# Altium schematic sheet uINS.SchDoc(U_uINS)
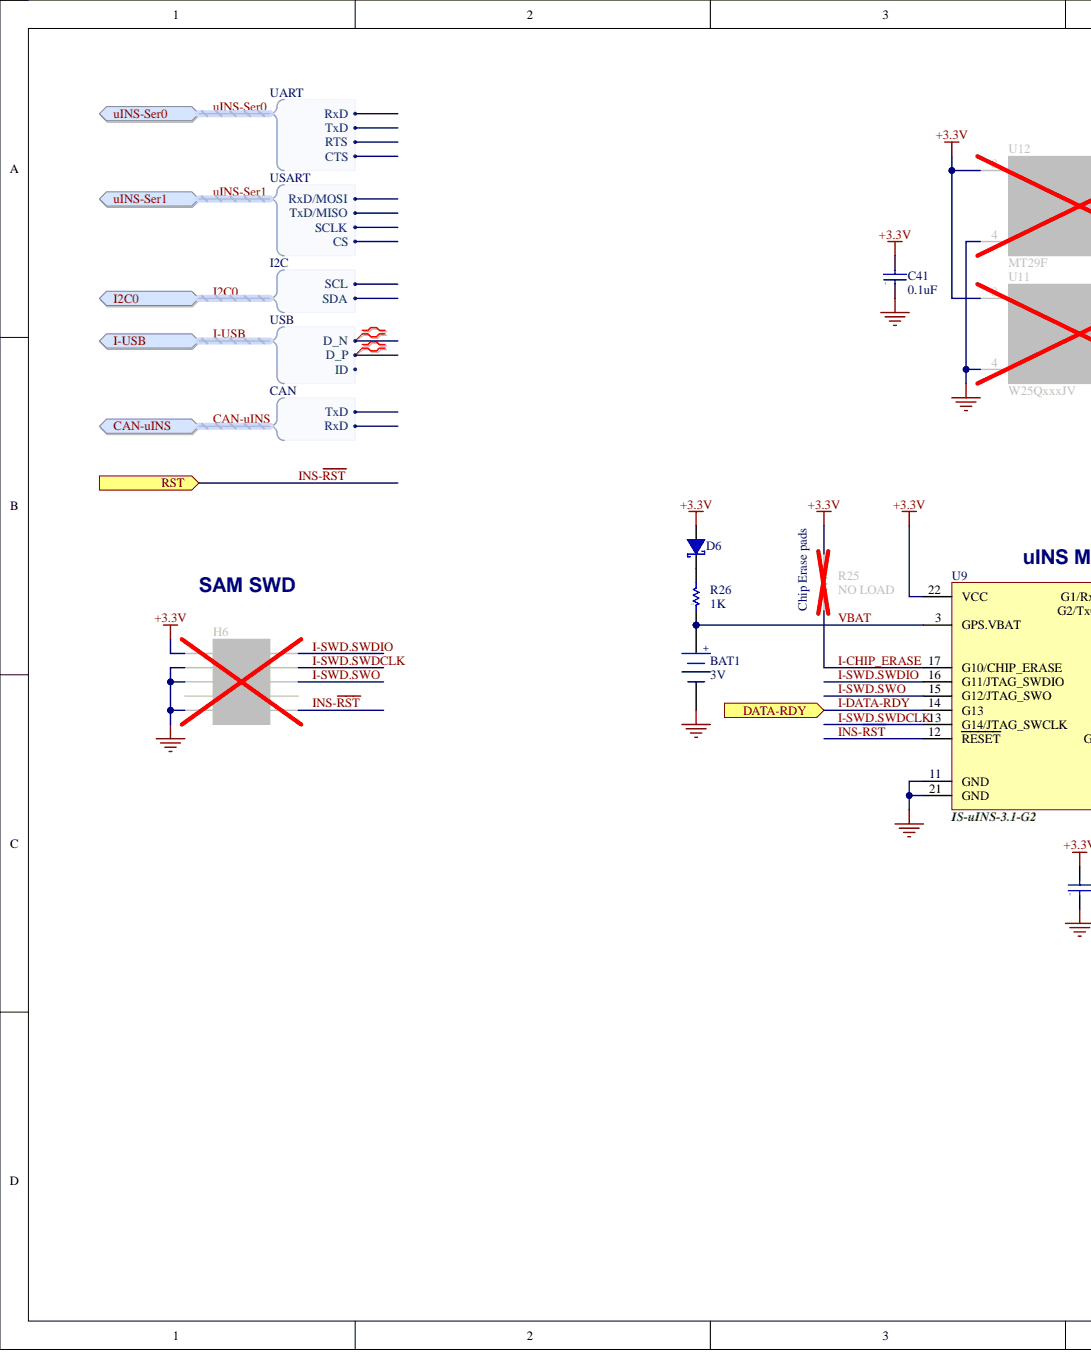
[diagram p0: uINS.SchDoc, left]
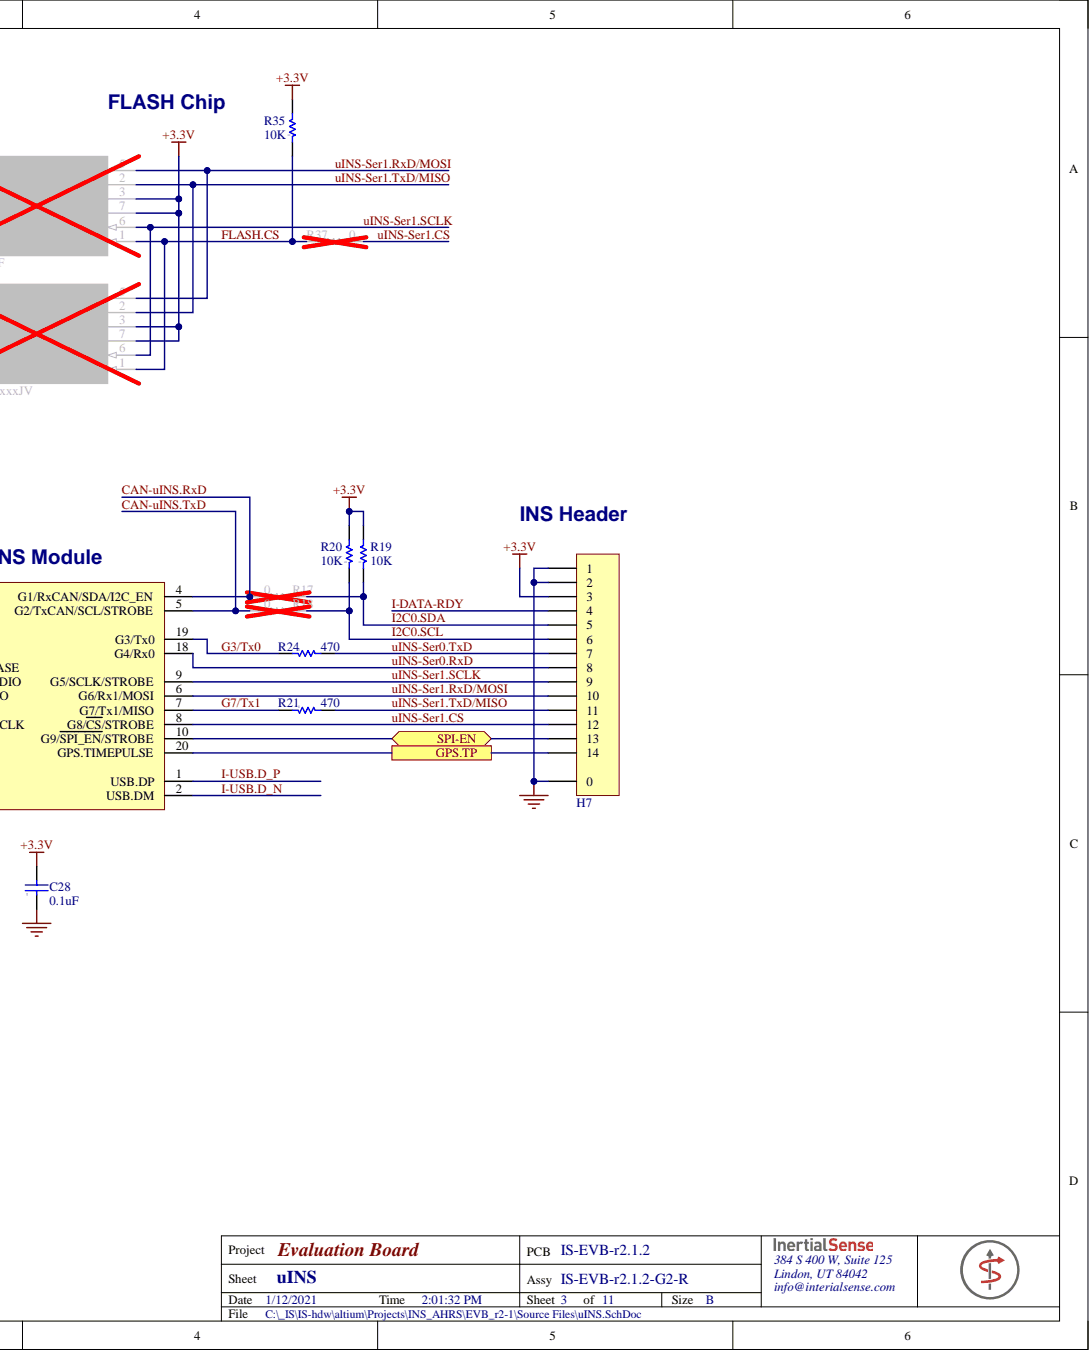
[diagram p1: uINS.SchDoc, right]

## Parts
BAT1  Voltage Rating: 3V  [ML614S]  (hidden comment: Battery)
C28  value 0.1uF  [0402]  (hidden comment: Cap)
C41  value 0.1uF  [0402]  (hidden comment: Cap)
D6  Diode - Schottky  [D0603B]  (value not drawn)
H6  Hdr - 5x2pin  [FTSH-105-01-L-DV-K-A-P]  (value not drawn)
H7  Hdr - 14pin - mount  [SM14B-GHS-TB(LF)(SN)]  (value not drawn)
R17  value 0  [R0402]  (hidden comment: Res)
R18  value 0  [R0402]  (hidden comment: Res)
R19  value 10K  [R0402]  (hidden comment: Res)
R20  value 10K  [R0402]  (hidden comment: Res)
R21  value 470  [R0402]  (hidden comment: Res)
R24  value 470  [R0402]  (hidden comment: Res)
R25  value NO LOAD  Resistance (Ohms): NO LOAD  [R0402]  (hidden comment: Res)
R26  value 1K  [R0402]  (hidden comment: Res)
R35  value 10K  [R0402]  (hidden comment: Res)
R37  value 0  [R0402]  (hidden comment: Res)
U9  uINS  Manufacturer Part Number: IS-uINS-3.1-G2  [IS-uINS-3.2_no_paste]
U11  W25QxxxJV  [SOIC127P790X216-8N]
U12  MT29F  [DFN800X600X65-8N]

## Ports
CAN-uINS, CAN-uINS.RxD, CAN-uINS.TxD, DATA-RDY, GPS.TP, I2C0, I2C0.SCL, I2C0.SDA, I-USB, I-USB.D_N, I-USB.D_P, I-USB.ID, SPI-EN, uINS-Ser0, uINS-Ser0.CTS, uINS-Ser0.RTS, uINS-Ser0.RxD, uINS-Ser0.TxD, uINS-Ser1, uINS-Ser1.CS, uINS-Ser1.RxD/MOSI, uINS-Ser1.SCLK, uINS-Ser1.TxD/MISO, ~{RST}

## Nets
+3.3V: C28.2 C41.2 D6.A H6.1 H7.3 R19.2 R20.2 R25.2 R35.2 U9.22(VCC) U11.3(WP/SIO2) U11.7(HOLD/SIO3) U11.8(VCC) U12.3(WP/SIO2) U12.7(HOLD/SIO3) U12.8(VCC)
GND: BAT1.- C28.1 C41.1 H6.3 H6.5 H6.9 H7.0 H7.1 H7.2 U9.11(GND) U9.21(GND) U11.4(GND) U12.4(GND)
CAN-uINS.RxD: R17.1 U9.4(G1/RxCAN/SDA/I2C_EN) ; port CAN-uINS
CAN-uINS.TxD: R18.1 U9.5(G2/TxCAN/SCL/STROBE) ; port CAN-uINS
FLASH.CS: R35.1 R37.1 U11.1(CS) U12.1(CS)
G3/Tx0: R24.1 U9.19(G3/Tx0)
G7/Tx1: R21.1 U9.7(G7/Tx1/MISO)
GPS.TP: H7.14 U9.20(GPS.TIMEPULSE) ; port GPS.TP
I2C0.SCL: H7.6 R18.2 R20.1 ; port I2C0
I2C0.SDA: H7.5 R17.2 R19.1 ; port I2C0
I-CHIP_ERASE: R25.1 U9.17(G10/CHIP_ERASE)
I-DATA-RDY: H7.4 U9.14(G13) ; port DATA-RDY
I-SWD.SWDCLK: H6.4 U9.13(G14/JTAG_SWCLK)
I-SWD.SWDIO: H6.2 U9.16(G11/JTAG_SWDIO)
I-SWD.SWO: H6.6 U9.15(G12/JTAG_SWO)
I-USB.D_N: U9.2(USB.DM) ; port I-USB
I-USB.D_P: U9.1(USB.DP) ; port I-USB
INS-~{RST}: H6.10 U9.12(RESET) ; port ~{RST}
SPI-EN: H7.13 U9.10(G9/SPI_EN/STROBE) ; port SPI-EN
uINS-Ser0.RxD: H7.8 U9.18(G4/Rx0) ; port uINS-Ser0
uINS-Ser0.TxD: H7.7 R24.2 ; port uINS-Ser0
uINS-Ser1.CS: H7.12 R37.2 U9.8(G8/CS/STROBE) ; port uINS-Ser1
uINS-Ser1.RxD/MOSI: H7.10 U9.6(G6/Rx1/MOSI) U11.5(DI/SIO0) U12.5(DI/SIO0) ; port uINS-Ser1
uINS-Ser1.SCLK: H7.9 U9.9(G5/SCLK/STROBE) U11.6(CLK) U12.6(CLK) ; port uINS-Ser1
uINS-Ser1.TxD/MISO: H7.11 R21.2 U11.2(DO/SIO1) U12.2(DO/SIO1) ; port uINS-Ser1
VBAT: BAT1.+ R26.1 U9.3(GPS.VBAT)
NetD6_C: D6.C R26.2
NetH6_7: H6.7
NetH6_8: H6.8
I-USB.ID: ; port I-USB
uINS-Ser0.CTS: ; port uINS-Ser0
uINS-Ser0.RTS: ; port uINS-Ser0

## Library data of the parts
BAT1 = Battery: BATTERY LITH 3V RECHARGE SMD; Manufacturer: Seiko; Manufacturer Part Number: MS621T-FL11E; Capacity: 3mAh; Component Kind: Standard; ComponentLink2Description: https://www.sii.co.jp/en/me/files/2018/04/MicroBattery_catalogue_E_2018_forWeb.pdf?src-supplier=Digi-Key; ComponentLink2URL: https://www.sii.co.jp/en/me/files/2018/04/MicroBattery_catalogue_E_2018_forWeb.pdf?src-supplier=Digi-Key; Height: 0.08inch; Library Name: General.SchLib; Pricing 1: 1=2.60000, 10=2.15200, 50=1.89740, 100=1.79140, 300=1.66420, 500=1.57940, 1000=1.49460, 2500=1.42040 (USD); RoHS: Yes; Stock 1: 1968; Supplier 1: Digi-Key; Supplier Part Number 1: 728-1080-ND; Termination: SMD/SMT; Weight: 0.23g
C28 C41 = Cap: CAP CER .10UF 50V X7R 10% 0402; Manufacturer: TDK Corporation; Manufacturer Part Number: C1005X7R1H104K; Capacitance: 0.1µF; Applications: General Purpose; Category: Capacitors; Component Kind: Standard; ComponentLink1Description: http://www.tdk.co.jp/tefe02/e412_c.pdf; ComponentLink1URL: http://www.tdk.co.jp/tefe02/e412_c.pdf; Features: -; Height: -; LatestRevisionDate: 17-Jul-2002; LatestRevisionNote: Re-released for DXP Platform.; Lead Spacing: -; Lead Style: -; Library Name: General.SchLib; Mounting Type: Surface Mount, MLCC; Operating Temperature: -55°C ~ 125°C; Package / Case: 0402 (1005 Metric); Packaging: Cut Tape (CT); Pricing 1: 1=0.3, 10=0.2, 100=0.08, 250=0.05752, 500=0.041, 1000=0.03 (USD); Published: 8-Jun-2000; Ratings: -; RoHS: RoHS Compliant; Signal Integrity: Cap; Simulation: CAP; Size / Dimension: 0.039" L x 0.020" W (1.00mm x 0.50mm); Stock 1: 174080; Supplier 1: Digi-Key; Supplier Part Number 1: 445-5932-1-ND; Temperature Coefficient: X7R; Thickness: 0.022" (0.55mm); Tolerance: ±10%; Voltage - Rated: 50V; Publisher: Altium Limited
D6 = Diode - Schottky: DIODE SCHOTTKY 30V 2A 0603; Manufacturer: Vishay; Manufacturer Part Number: VSKY20301608-G4-08; Component Kind: Standard; ComponentLink1Description: http://www.mouser.com/ds/2/427/vsky20301608-777242.pdf; ComponentLink1URL: http://www.mouser.com/ds/2/427/vsky20301608-777242.pdf; Library Name: General.SchLib; Pricing 1: 1=0.38, 10=0.305, 25=0.2792, 100=0.2076, 250=0.1882, 500=0.15574, 1000=0.1168, 2500=0.10707 (USD); Simulation: SKYDIODE; Stock 1: 13064; Supplier 1: Digi-Key; Supplier Part Number 1: VSKY20301608-G4-08GICT-ND
H6 = Hdr - 5x2pin: CONN HEADER 10POS DUAL .05" SMD; Manufacturer: Samtec; Gender: Male; Number of Contacts: 10; Pitch: 1.27mm; Component Kind: Standard; ComponentLink1Description: http://www.samtec.com/documents/webfiles/pdf/FTSH_SMT.pdf; ComponentLink1URL: http://www.samtec.com/documents/webfiles/pdf/FTSH_SMT.pdf; Contact Material: Bronze; Contact Plating: Gold; Depth: 3.43mm; Housing Colour: Black; Housing Material: Polymer; Lead Free: Lead Free; Length: 6.35mm; Library Name: Connectors.SchLib; Manufacturer Part Number: FTSH-105-01-L-DV-K-A-P; Max Operating Temperature: 125°C; Min Operating Temperature: -55°C; Number of Rows: 2; Orientation: Straight; Packaging: Bulk; Pricing 1: 1=4.15, 92=3.48598, 184=3.32, 552=2.82199, 1012=2.407 (USD); Radiation Hardening: No; Stock 1: 3679; Supplier 1: Digi-Key; Supplier Part Number 1: SAM9440-ND; Termination: Solder
H7 = Hdr - 14pin - mount: CONN HEADER GH SIDE 14POS 1.25MM; Manufacturer: JST; Connector Type: Outer Shroud Contact; Current Rating: 1A; Gender: Male; Number of Contacts: 14; Pitch: 0.049inch; Voltage Rating (AC): 50V; Wire/Cable Gauge: 26AWG; Colour: Natural; Component Kind: Standard; Contact Material: Bronze; Contact Plating: Tin; Fastening Type: Ramp; Housing Colour: Natural; Housing Material: Nylon; Lead Free: Lead Free; Library Name: Connectors.SchLib; Manufacturer Part Number: SM14B-GHS-TB(LF)(SN); Max Operating Temperature: 85°C; Min Operating Temperature: -25°C; Mount: Surface Mount; Number of Positions: 14; Number of Rows: 1; Packaging: Tape and Reel; REACH SVHC: No SVHC; Stock 1: 0; Supplier 1: Digi-Key; Supplier Part Number 1: 455-1576-6-ND; Termination: Solder
R17 R18 R37 = Res: RES SMD 0 OHM JUMPER 1/16W 0402; Manufacturer: Yageo; Manufacturer Part Number: RC0402JR-070RL; Max Power Dissipation: 63mW; Power Rating: 62.5mW; Resistance: 0mR; Resistance Tolerance: ± 5%; Resistor Case Style: 0402 [1005 Metric]; Resistor Element Type: Thick Film; SVHC: No SVHC (20-Jun-2016); Temperature Coefficient: 200ppm/°C; Tolerance: 5%; Voltage Rating: 50V; Automotive Qualification Standard: -; Case Code (Imperial): 0402; Case Code (Metric): 1005; Case/Package: 0402; Component Kind: Standard; ComponentLink1Description: https://datasheet.ciiva.com/2452/pyu-rc0402-51-rohs-l-6-2452608.pdf; ComponentLink1URL: https://datasheet.ciiva.com/2452/pyu-rc0402-51-rohs-l-6-2452608.pdf; ComponentLink2Description: https://datasheet.ciiva.com/2452/pyu-r-int-thick-7-2452609.pdf; ComponentLink2URL: https://datasheet.ciiva.com/2452/pyu-r-int-thick-7-2452609.pdf; ComponentLink3Description: https://datasheet.ciiva.com/2452/pyu-r-int-thick-7-2452610.pdf; ComponentLink3URL: https://datasheet.ciiva.com/2452/pyu-r-int-thick-7-2452610.pdf; ComponentLink4Description: https://datasheet.ciiva.com/11808/0900766b80c66297-11808195.pdf; ComponentLink4URL: https://datasheet.ciiva.com/11808/0900766b80c66297-11808195.pdf; ComponentLink5Description: https://datasheet.ciiva.com/8896/pyu-rc0402-51-rohs-l-6-8896201.pdf; ComponentLink5URL: https://datasheet.ciiva.com/8896/pyu-rc0402-51-rohs-l-6-8896201.pdf; ComponentLink6Description: https://datasheet.ciiva.com/28386/pyu-rc0402-51-rohs-l-6-28386633.pdf; ComponentLink6URL: https://datasheet.ciiva.com/28386/pyu-rc0402-51-rohs-l-6-28386633.pdf; ComponentLink7Description: https://datasheet.ciiva.com/22747/1018594-22747515.pdf; ComponentLink7URL: https://datasheet.ciiva.com/22747/1018594-22747515.pdf; ComponentLink8Description: https://datasheet.ciiva.com/15189/getdatasheetpartid-326379-15189533.pdf; ComponentLink8URL: https://datasheet.ciiva.com/15189/getdatasheetpartid-326379-15189533.pdf; ComponentLink9Description: https://datasheet.ciiva.com/7983/1018594-7983535.pdf; ComponentLink9URL: https://datasheet.ciiva.com/7983/1018594-7983535.pdf; Composition: Thick Film; Contact Plating: Matte,Tin; Depth: 0.5mm; Features: Moisture Resistant; Height: 0.016inch; Lead Free: Lead Free; Length: 1mm; Library Name: General.SchLib; Max Operating Temperature: 155°C; Military Standard: Not; Min Operating Temperature: -55°C; MSL: MSL 1 - Unlimited; Number of Terminations: 2; Package Quantity: 1; Packaging: Tape and Reel; Pricing 1: 1=0.1, 10=0.017, 25=0.0124, 50=0.0096, 100=0.007, 250=0.00536, 500=0.00428, 1000=0.00315, 5000=0.00226 (USD); Product Range: -; Radiation Hardening: No; REACH SVHC: Unknown; Signal Integrity: Res; Simulation: RES; Stock 1: 39749506; Supplier 1: Digi-Key; Supplier Part Number 1: 311-0.0JRDKR-ND; Termination: SMD/SMT; Width: 0.02inch
R19 R20 R35 = Res: RES 10K OHM 1/16W 1% 0402 SMD; Manufacturer: Panasonic; Manufacturer Part Number: ERJ-2RKF1002X; Max Power Dissipation: 100mW; Power Rating: 62.5mW; Resistance: 10kR; Temperature Coefficient: 100ppm/°C; Voltage Rating: 50V; Case Code (Imperial): 0402; Case Code (Metric): 1005; Case/Package: 0402; Component Kind: Standard; ComponentLink1Description: http://www.yageo.com/documents/recent/PYu-RC0402_51_RoHS_L_6.pdf; ComponentLink1URL: http://www.yageo.com/documents/recent/PYu-RC0402_51_RoHS_L_6.pdf; ComponentLink2Description: https://datasheet.ciiva.com/7660/38568-7660787.pdf; ComponentLink2URL: https://datasheet.ciiva.com/7660/38568-7660787.pdf; ComponentLink3Description: https://datasheet.ciiva.com/11808/0900766b80c66297-11808309.pdf; ComponentLink3URL: https://datasheet.ciiva.com/11808/0900766b80c66297-11808309.pdf; ComponentLink4Description: https://datasheet.ciiva.com/7849/1784430-7849813.pdf; ComponentLink4URL: https://datasheet.ciiva.com/7849/1784430-7849813.pdf; ComponentLink5Description: https://datasheet.ciiva.com/16744/pyu-rc0402-51-rohs-l-6-r-16744005.pdf; ComponentLink5URL: https://datasheet.ciiva.com/16744/pyu-rc0402-51-rohs-l-6-r-16744005.pdf; ComponentLink6Description: https://datasheet.ciiva.com/7849/1686128-7849814.pdf; ComponentLink6URL: https://datasheet.ciiva.com/7849/1686128-7849814.pdf; ComponentLink7Description: https://datasheet.ciiva.com/7849/1784430-7849815.pdf; ComponentLink7URL: https://datasheet.ciiva.com/7849/1784430-7849815.pdf; ComponentLink8Description: https://datasheet.ciiva.com/22747/1018594-22747512.pdf; ComponentLink8URL: https://datasheet.ciiva.com/22747/1018594-22747512.pdf; ComponentLink9Description: https://datasheet.ciiva.com/12667/pyu-rc0402-51-rohs-l-6-r-12667067.pdf; ComponentLink9URL: https://datasheet.ciiva.com/12667/pyu-rc0402-51-rohs-l-6-r-12667067.pdf; ComponentLink10Description: https://datasheet.ciiva.com/7983/1018594-7983486.pdf; ComponentLink10URL: https://datasheet.ciiva.com/7983/1018594-7983486.pdf; Composition: Thick Film; Contact Plating: Tin; Depth: 0.5mm; Features: Automotive AEC-Q200; Height: 0.35mm; LatestRevisionDate: 2-Dec-2009; Lead Free: Lead Free; Lead Pitch: 0.2mm; Length: 1mm; Library Name: General.SchLib; Max Operating Temperature: 155°C; Military Standard: Not; Min Operating Temperature: -55°C; Mount: Surface Mount; Number of Pins: 2; Number of Terminations: 2; Package Quantity: 1; Packaging: Tape and Reel; Pricing 1: 1=0.1, 10=0.045, 100=0.0184, 1000=0.00826, 2500=0.00717, 5000=0.00593 (USD); Pricing 2: 1=0.1, 10=0.018, 100=0.0073, 1000=0.00329, 2500=0.00286, 5000=0.00236 (USD); Radiation Hardening: No; REACH SVHC: No SVHC; Signal Integrity: Res; Simulation: RES; Stock 1: 28312782; Stock 2: 0; Supplier 1: Digi-Key; Supplier 2: Digi-Key; Supplier Part Number 1: P10.0KLDKR-ND; Supplier Part Number 2: 311-10.0KLRDKR-ND; Termination: SMD/SMT; Tolerance: 1%; Voltage Rating (DC): 50V; Width: 0.5mm; Working Voltage: 50V
R21 R24 = Res: RES 470 OHM 1/16W 1% 0402 SMD; Manufacturer: Vishay Dale; Manufacturer Part Number: CRCW0402470RFKED; Power (Watts): 0.063W, 1/16W; Temperature Coefficient: ±100ppm/°C; Category: Resistors; Component Kind: Standard; ComponentLink1Description: http://www.vishay.com/doc?20035; ComponentLink1URL: http://www.vishay.com/doc?20035; Composition: Thick Film; Features: Automotive AEC-Q200 Qualified; Height: 0.016" (0.40mm); Library Name: General.SchLib; Number of Terminations: 2; Package / Case: 0402 (1005 Metric); Packaging: Cut Tape (CT); Pricing 1: 10=0.083, 50=0.0444, 200=0.0255, 1000=0.0174 (USD); Resistance (Ohms): 470; RoHS: RoHS Compliant; Signal Integrity: Res; Simulation: RES; Size / Dimension: 0.039" L x 0.020" W (1.00mm x 0.50mm); Stock 1: 121698; Supplier 1: Digi-Key; Supplier Device Package: 0402 (1005 Metric); Supplier Part Number 1: 541-470LCT-ND; Tolerance: ±1%
R25 = Res: RES 1.00K OHM 1/16W 1% 0402 SMD; Power (Watts): 0.063W, 1/16W; Category: Resistors; Component Kind: Standard; ComponentLink1Description: http://www.yageo.com/documents/recent/PYu-RC0402_51_RoHS_L_6.pdf; ComponentLink1URL: http://www.yageo.com/documents/recent/PYu-RC0402_51_RoHS_L_6.pdf; Composition: Thick Film; Features: -; Height: 0.016" (0.40mm); Library Name: General.SchLib; Number of Terminations: 2; Package / Case: 0402 (1005 Metric); Packaging: Cut Tape (CT); Pricing 1: 1=0.1, 10=0.014, 100=0.0063, 500=0.00382, 1000=0.0027, 5000=0.00203 (USD); RoHS: RoHS Compliant; Signal Integrity: Res; Simulation: RES; Size / Dimension: 0.039" L x 0.020" W (1.00mm x 0.50mm); Stock 1: 3222170
R26 = Res: RES 1.00K OHM 1/16W 1% 0402 SMD; Manufacturer: Yageo; Manufacturer Part Number: RC0402FR-071KL; Power (Watts): 0.063W, 1/16W; Temperature Coefficient: ±100ppm/°C; Category: Resistors; Component Kind: Standard; ComponentLink1Description: http://www.yageo.com/documents/recent/PYu-RC0402_51_RoHS_L_6.pdf; ComponentLink1URL: http://www.yageo.com/documents/recent/PYu-RC0402_51_RoHS_L_6.pdf; Composition: Thick Film; Features: -; Height: 0.016" (0.40mm); LatestRevisionDate: 2-Dec-2009; Library Name: General.SchLib; Number of Terminations: 2; Package / Case: 0402 (1005 Metric); Packaging: Cut Tape (CT); Pricing 1: 1=0.1, 10=0.014, 100=0.0063, 500=0.00382, 1000=0.0027, 5000=0.00203 (USD); Resistance (Ohms): 1k; RoHS: RoHS Compliant; Signal Integrity: Res; Simulation: RES; Size / Dimension: 0.039" L x 0.020" W (1.00mm x 0.50mm); Stock 1: 3222170; Supplier 1: Digi-Key; Supplier Part Number 1: 311-1.00KLRCT-ND; Tolerance: ±1%
U9 = IS-uINS-3.2: Inertial Sense uINS; Component Kind: Standard; Library Name: InertialSense.SchLib; Manufacturer: Inertial Sense; Supplier 1: Inertial Sense; Supplier Part Number 1: IS-uINS-3.1-G2
U11 = W25Q128JV: IC FLASH 128M SPI 133MHZ 8SOIC; Manufacturer: Winbond; Manufacturer Part Number: W25Q128JVSIQTR; Frequency: 104MHz; Interface: Serial,SPI; Memory Type: FLASH,NOR; Case/Package: SOIC; Component Kind: Standard; Library Name: ICs.SchLib; Max Operating Temperature: 85°C; Min Operating Temperature: -40°C; Packaging: Tape and Reel; Pricing 1: 1=2.2, 10=2.006, 25=1.9528, 50=1.9424, 100=1.7362, 250=1.73108, 500=1.70532, 750=1.67955, 1000=1.63215 (USD); Stock 1: 88262; Supplier 1: Digi-Key; Supplier Part Number 1: W25Q128JVSIQCT-ND
U12 = MT29F: IC FLASH 1G/2G SPI 8UPDFN; Manufacturer: Micron Technology; Manufacturer Part Number: MT29F2G01ABAGDWB-IT:GTR; Interface: Serial,SPI; Memory Type: FLASH,NAND; Component Kind: Standard; Library Name: ICs.SchLib; Max Operating Temperature: 85°C; Min Operating Temperature: -40°C; Pricing 1: 1=5.24, 10=4.756, 25=4.6528, 50=4.627, 100=4.1496, 250=4.13412, 500=3.98186, 1000=3.78574 (USD); Stock 1: 7067; Supplier 1: Digi-Key; Supplier Part Number 1: 557-1664-6-ND
